# Altium schematic sheet fire_warning.SchDoc(fire_warning)
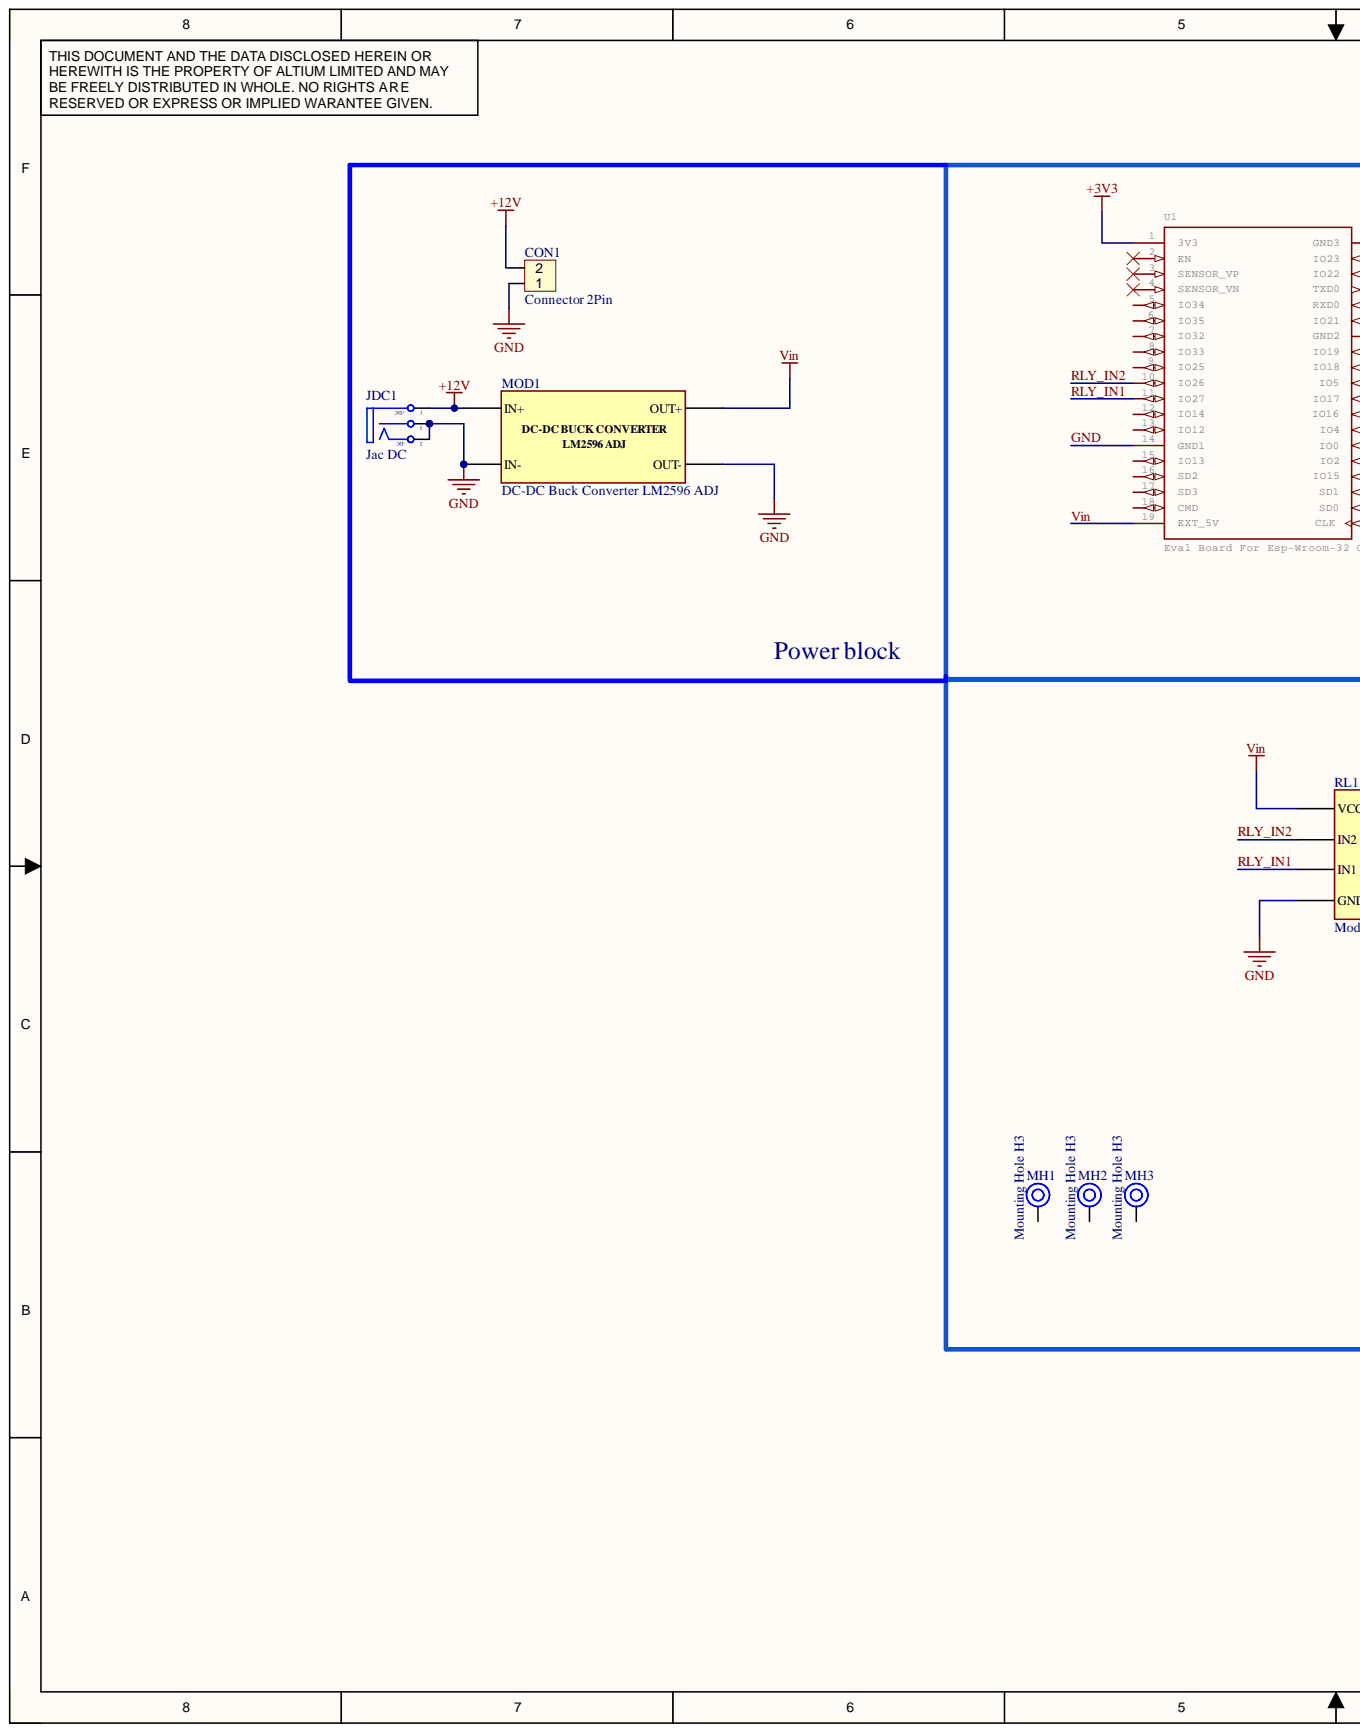
[diagram p0: fire_warning.SchDoc, left]
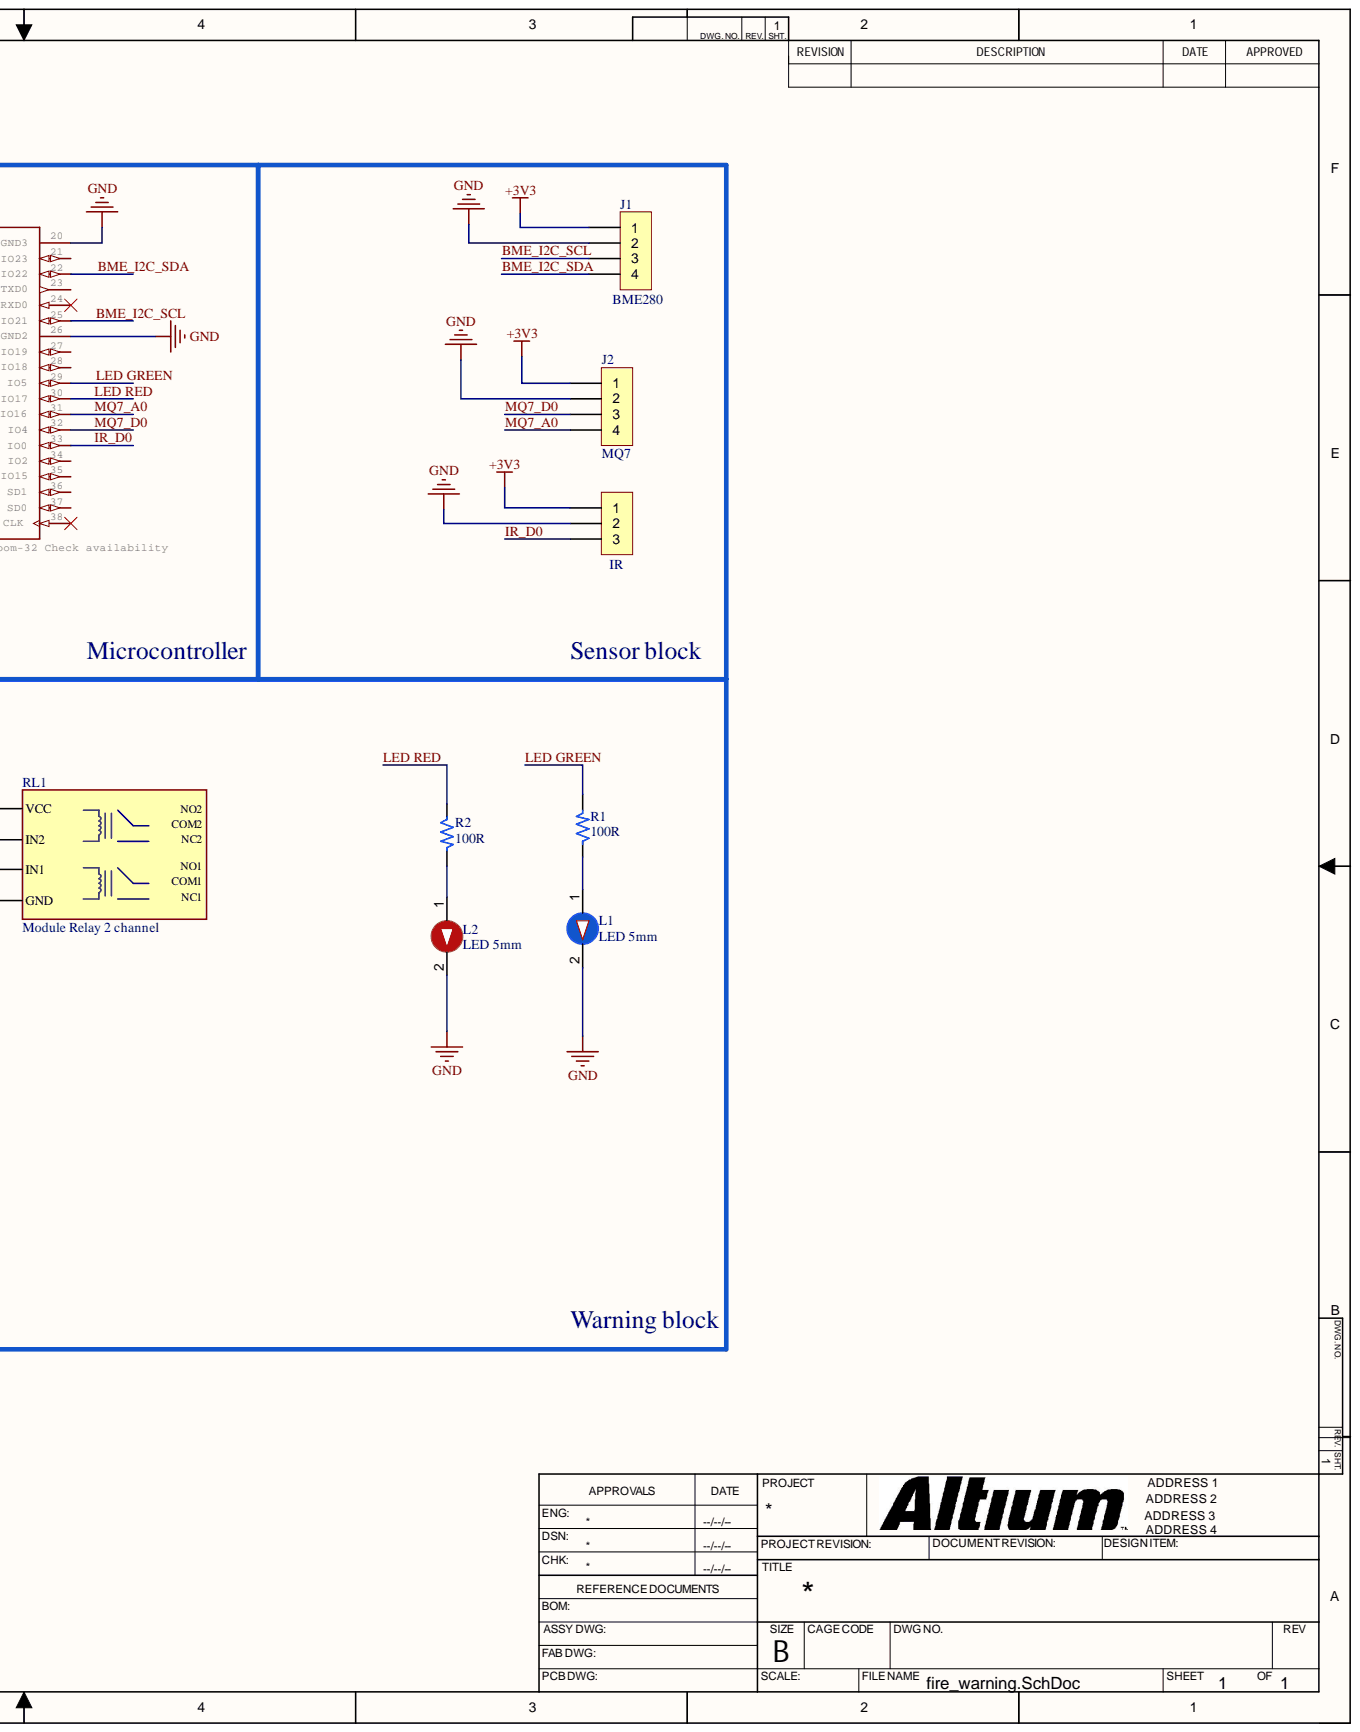
[diagram p1: fire_warning.SchDoc, right]

## Parts
CON1  Connector 2Pin  [Connector 2 Pin]
J1  BME280  [XH2.54M-4]
J2  MQ7  [XH2.54M-4]
J3  IR  [XH2.54M-3]
JDC1  Jac DC  [DC-IN]
L1  LED 5mm  [LED-5MM-BLUE]
L2  LED 5mm  [LED-5MM-RED]
MH1  Mounting Hole H3  [Mounting Hole H3]
MH2  Mounting Hole H3  [Mounting Hole H3]
MH3  Mounting Hole H3  [Mounting Hole H3]
MOD1  DC-DC Buck Converter LM2596 ADJ  [Module DC-DC Buck Converter LM2596]
R1  100R  [Res 1/4W]
R2  100R  [Res 1/4W]
RL1  Module Relay 2 channel  [RLY 2 Channel]
U1  Eval Board For Esp-Wroom-32 Check availability  [MODULE_ESP32-DEVKITC-32D]

## Nets
+3V3: J1.1 J2.1 J3.1 U1.1(3V3)
+12V: CON1.2 JDC1.1 MOD1.1(IN+)
BME_I2C_SCL: J1.3 U1.25(IO21)
BME_I2C_SDA: J1.4 U1.22(IO22)
GND: CON1.1 J1.2 J2.2 J3.2 JDC1.2 JDC1.3 L1.2 L2.2 MOD1.2(IN-) MOD1.4(OUT-) RL1.4(GND) U1.14(GND1) U1.20(GND3) U1.26(GND2)
IR_D0: J3.3 U1.33(IO0)
LED GREEN: R1.2 U1.29(IO5)
LED RED: R2.1 U1.30(IO17)
MQ7_A0: J2.4 U1.31(IO16)
MQ7_D0: J2.3 U1.32(IO4)
RLY_IN1: RL1.3(IN1) U1.11(IO27)
RLY_IN2: RL1.2(IN2) U1.10(IO26)
Vin: MOD1.3(OUT+) RL1.1(VCC) U1.19(EXT_5V)
NetL1_1: L1.1 R1.1
NetL2_1: L2.1 R2.2

Not connected: MH1 1; MH2 1; MH3 1; U1 2(EN) 3(SENSOR_VP) 4(SENSOR_VN) 5(IO34) 6(IO35) 7(IO32) 8(IO33) 9(IO25) 12(IO14) 13(IO12) 15(IO13) 16(SD2) 17(SD3) 18(CMD) 21(IO23) 23(TXD0) 24(RXD0) 27(IO19) 28(IO18) 34(IO2) 35(IO15) 36(SD1) 37(SD0) 38(CLK)

## Library data of the parts
CON1 = Connector 2Pin: Value: Header 2; Component Kind: Standard; Library Name: AD_Lib.SchLib
J1 J2 = CON 4-Pin: Pitch 2.54mm; Chung_Loai: Cắm; Don_Vi: Con; Ma_Hang: MH001285; Ten_Linh_Kien: Header 4P XH2.54-4P Đực Thẳng; Component Kind: Standard; Library Name: AD_Lib.SchLib
J3 = CON 3-Pin: Chung_Loai: Cắm; Don_Vi: Con; Ma_Hang: MH001292; Ten_Linh_Kien: Header 3P XH2.54-3P Đực Thẳng; Component Kind: Standard; Library Name: AD_Lib.SchLib
JDC1 = DCIN: Jac DC input; Component Kind: Standard; Library Name: AD_Lib.SchLib; Value: Jac DC
L1 = LED 5mm Xanh Duong: Cắm; Don_Vi: Con; Ma_Hang: MH008987; Ten_Linh_Kien: Led 5MM Xanh Dương Đục (1000c); Chung_Loai: Cắm; Component Kind: Standard; Library Name: Altium_Lib_by_KhueNguyenCreator.IntLib
L2 = LED 5mm Do: Cắm; Don_Vi: Con; Ma_Hang: MH008967; Ten_Linh_Kien: Led 5MM Phủ Đỏ (1000c); Chung_Loai: Cắm; Component Kind: Standard; Library Name: Altium_Lib_by_KhueNguyenCreator.IntLib
MH1-MH3 = MH_3H: Mounting Hole H3mm; Alternate Part Number-1: NA; Case/Package: TH; Component Kind: Standard; Library Name: AD_Lib.SchLib; Manufacturer: NA; Manufacturer Part Number: NA; Supplier Part Number-1: NA; Supplier-1: NA
MOD1 = MOD-DC-DC_Buck_LM2596_3A: Input: 3-30V, Output:1.5-30V. I_out = 3A (max). Dimensions: 45x20x14mm; Component Kind: Standard; Library Name: AD_Lib.SchLib
R1 R2 = Res: Resistor; Value: R1/4W - 1K; Component Kind: Standard; Library Name: AD_Lib.SchLib
RL1 = RLY2_5V_80mA: Output: 10A/30VDC, 10A/250VAC; Component Kind: Standard; Library Name: AD_Lib.SchLib
U1 = ESP32-DEVKITC-32D: GateName_1: G$1; MF: Espressif Systems; Availability: In Stock; Component Kind: Standard; DeviceSetName: ESP32-DEVKITC-32D; Library Name: Module.IntLib; LibraryName: ESP32-DEVKITC-32D; MP: ESP32-DEVKITC-32D; Package: MODULE_ESP32-DEVKITC-32D; Price: None; Purchase-URL: https://pricing.snapeda.com/search/part/ESP32-DEVKITC-32D/?ref=eda; SymbolName_1: ESP32-DEVKITC-32D
